# Altium schematic sheet mimsy2.SchDoc
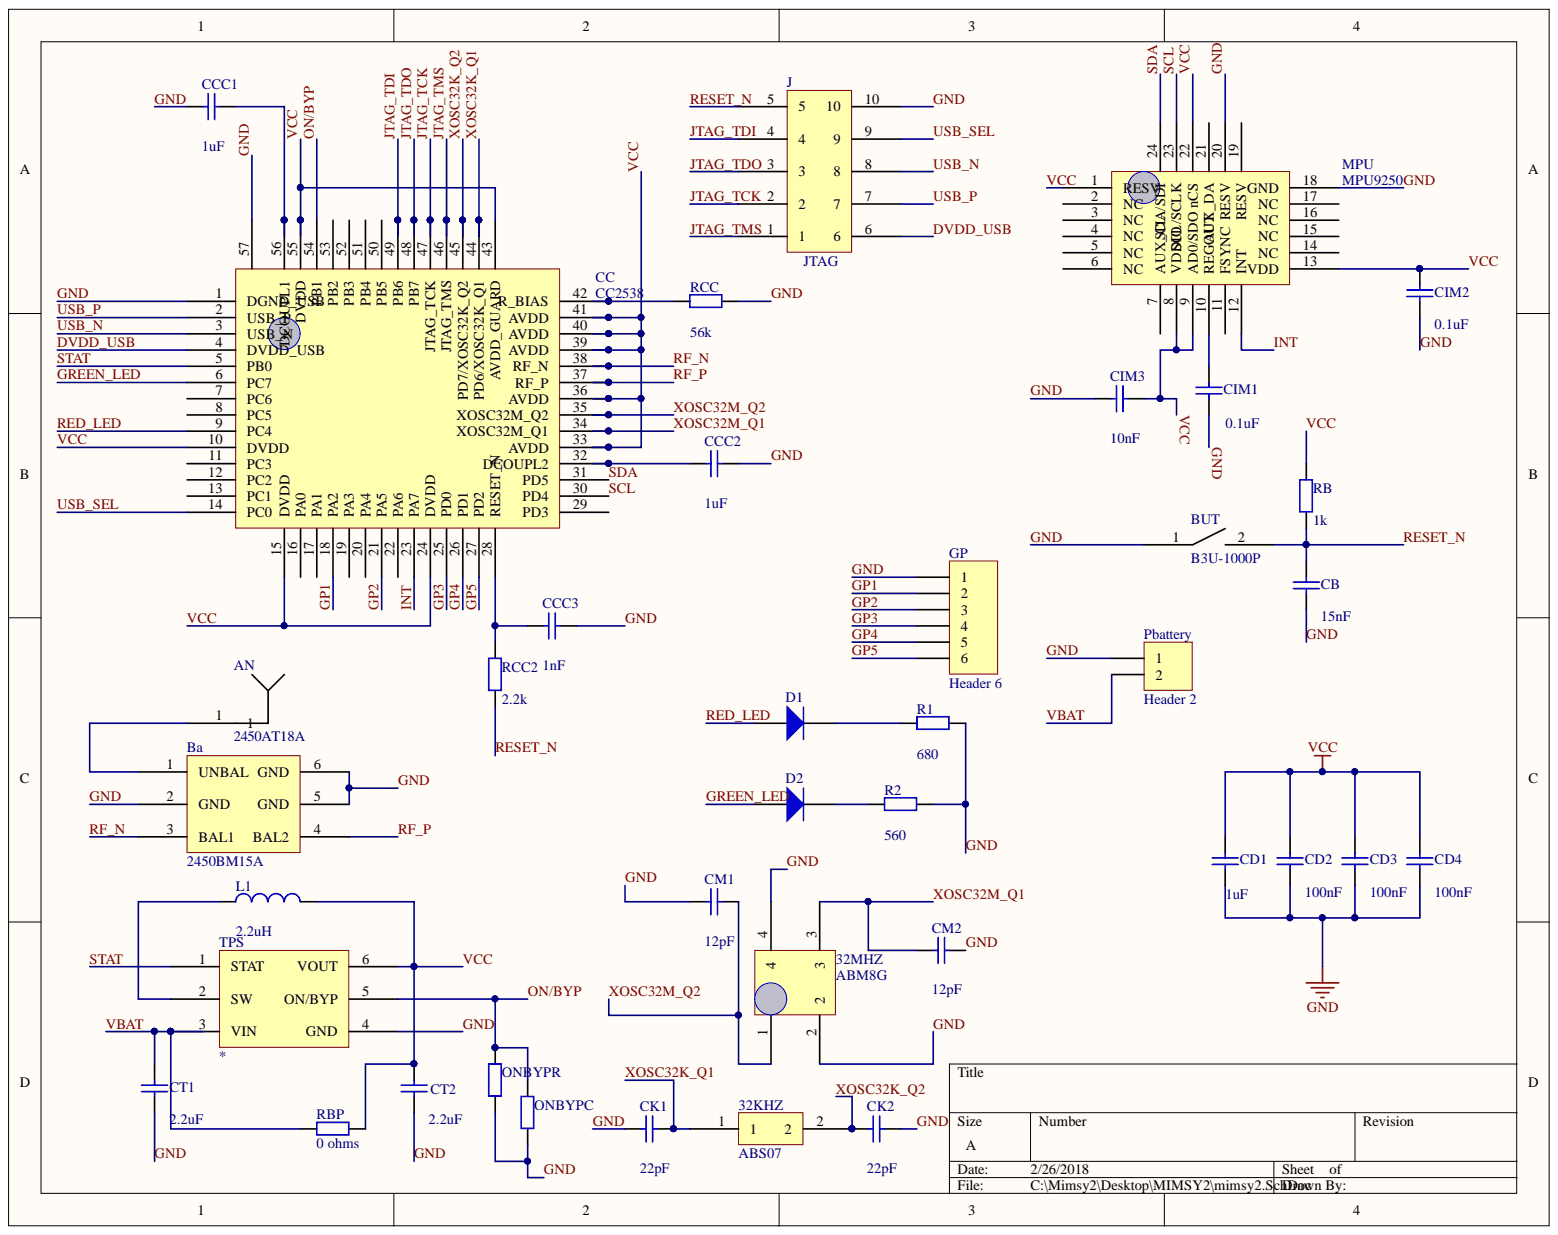
[diagram p0: mimsy2.SchDoc]

## Parts
32KHZ  ABS07  [32K Xtal]
32MHZ  ABM8G  [ABM8G]
AN  2450AT18A  [2450AT]
Ba  2450BM15A  [2450BM15A]
BUT  B3U-1000P  [B3U-1000P]
CB  value 15nF  [0402]
CC  CC2538  [QFN127P600-8N - NO GROUND PADS]
CCC1  value 1uF  [0402]
CCC2  value 1uF  [0402]
CCC3  value 1nF  [0402]
CD1  value 1uF  [0402]
CD2  value 100nF  [0402]
CD3  value 100nF  [0402]
CD4  value 100nF  [0402]
CIM1  value 0.1uF  [0402]
CIM2  value 0.1uF  [0402]
CIM3  value 10nF  [0402]
CK1  value 22pF  [0402]
CK2  value 22pF  [0402]
CM1  value 12pF  [0402]
CM2  value 12pF  [0402]
CT1  value 2.2uF  [0402]
CT2  value 2.2uF  [0402]
D1  (no value)  [0402-A]
D2  (no value)  [0402-A]
GP  Header 6  [HDR1X6]
J  JTAG  [JTAG2x5]
L1  value 2.2uH  [0402-A]
MPU  MPU9250  [QFN40P300X300X105-25N]
ONBYPC  (no value)  [0402]
ONBYPR  (no value)  [0402]
Pbattery  Header 2  [HDR1X2 - 2mm pitch]
R1  value 680  [0402]
R2  value 560  [0402]
RB  value 1k  [0402]
RBP  0 ohms  [0402]
RCC  value 56k  [0402]
RCC2  value 2.2k  [0402]
TPS  (no value)  [TPS62730]

## Nets
DVDD_USB: CC.4(DVDD_USB) J.6
GND: 32MHZ.2 32MHZ.4 Ba.2(GND) Ba.5(GND) Ba.6(GND) BUT.1 CB.1 CC.1(DGND_USB) CC.57 CCC1.1 CCC2.2 CCC3.2 CD1.1 CD2.1 CD3.1 CD4.1 CIM1.1 CIM2.1 CIM3.1 CK1.1 CK2.2 CM1.1 CM2.2 CT1.1 CT2.1 GP.1 J.10 MPU.18(GND) MPU.20(RESV) ONBYPC.1 ONBYPR.1 Pbattery.1 R1.2 R2.2 RCC.2 TPS.4(GND)
GP1: CC.18(PA2) GP.2
GP2: CC.21(PA5) GP.3
GP3: CC.25(PD0) GP.4
GP4: CC.26(PD1) GP.5
GP5: CC.27(PD2) GP.6
GREEN_LED: CC.6(PC7) D2.1
INT: CC.23(PA7) MPU.12(INT)
JTAG_TCK: CC.47(JTAG_TCK) J.2
JTAG_TDI: CC.49(PB6) J.4
JTAG_TDO: CC.48(PB7) J.3
JTAG_TMS: CC.46(JTAG_TMS) J.1
ON/BYP: CC.54(PB1) ONBYPC.2 ONBYPR.2 TPS.5(ON/BYP)
RED_LED: CC.9(PC4) D1.1
RESET_N: BUT.2 CB.2 J.5 RB.1 RCC2.1
RF_N: Ba.3(BAL1) CC.38(RF_N)
RF_P: Ba.4(BAL2) CC.37(RF_P)
SCL: CC.30(PD4) MPU.23(SCL/SCLK)
SDA: CC.31(PD5) MPU.24(SDA/SDI)
STAT: CC.5(PB0) TPS.1(STAT)
USB_N: CC.3(USB_N) J.8
USB_P: CC.2(USB_P) J.7
USB_SEL: CC.14(PC0) J.9
VBAT: CT1.2 Pbattery.2 RBP.1 TPS.3(VIN)
VCC: CC.10(DVDD) CC.15(DVDD) CC.24(DVDD) CC.33(AVDD) CC.36(AVDD) CC.39(AVDD) CC.40(AVDD) CC.41(AVDD) CC.43(AVDD_GUARD) CC.55(DVDD) CD1.2 CD2.2 CD3.2 CD4.2 CIM2.2 CIM3.2 CT2.2 L1.2 MPU.1(RESV) MPU.8(VDDIO) MPU.9(AD0/SDO) MPU.13(VDD) MPU.22(nCS) RB.2 RBP.2 TPS.6(VOUT)
XOSC32K_Q1: 32KHZ.1 CC.44(PD6/XOSC32K_Q1) CK1.2
XOSC32K_Q2: 32KHZ.2 CC.45(PD7/XOSC32K_Q2) CK2.1
XOSC32M_Q1: 32MHZ.3 CC.34(XOSC32M_Q1) CM2.1
XOSC32M_Q2: 32MHZ.1 CC.35(XOSC32M_Q2) CM1.2
NetAN_1: AN.1 Ba.1(UNBAL)
NetCC_28: CC.28(RESET_N) CCC3.1 RCC2.2
NetCC_32: CC.32(DCOUPL2) CCC2.1
NetCC_42: CC.42(R_BIAS) RCC.1
NetCC_56: CC.56(DCOUPL1) CCC1.2
NetCIM1_2: CIM1.2 MPU.10(REGOUT)
NetD1_2: D1.2 R1.1
NetD2_2: D2.2 R2.1
NetL1_1: L1.1 TPS.2(SW)

Not connected: CC 7(PC6) 8(PC5) 11(PC3) 12(PC2) 13(PC1) 16(PA0) 17(PA1) 19(PA3) 20(PA4) 22(PA6) 29(PD3) 50(PB5) 51(PB4) 52(PB3) 53(PB2); MPU 2(NC) 3(NC) 4(NC) 5(NC) 6(NC) 7(AUX_CL) 11(FSYNC) 14(NC) 15(NC) 16(NC) 17(NC) 19(RESV) 21(AUX_DA)

## Library data of the parts
32KHZ = ABS07: 32kHz Xtal; Component Kind: Standard; Library Name: Schlib1.SchLib
32MHZ = ABM8G: 32MH Xtal; Component Kind: Standard; Library Name: Schlib1.SchLib
AN = 2450AT18A: 2.4Ghz Ceramic Antenna; Component Kind: Standard; Library Name: Integrated_Library.IntLib
Ba = 2450BM15A: 2.4GHz Balun; Component Kind: Standard; Library Name: Integrated_Library.IntLib
CB CCC1 CCC2 CD1-CD4 CIM1-CIM3 CK1 CK2 CM1 CM2 CT1 CT2 = Cap Semi: Capacitor (Semiconductor SIM Model); Component Kind: Standard; LatestRevisionDate: 29-May-2009; LatestRevisionNote: IPC-7351 Footprint Added.; Library Name: Miscellaneous Devices.IntLib; PackageDescription: Chip Capacitor; PackageReference: C1206; Published: 8-Jun-2000; Signal Integrity: Cap Semi; Simulation: CAP; Publisher: Altium Limited
CC = CC2538: 56-VFQFN; Component Kind: Standard; Library Name: Schlib1.SchLib
CCC3 = Cap Semi: Capacitor (Semiconductor SIM Model); Value: 1nF; Component Kind: Standard; LatestRevisionDate: 29-May-2009; LatestRevisionNote: IPC-7351 Footprint Added.; Library Name: Miscellaneous Devices.IntLib; PackageDescription: Chip Capacitor; PackageReference: C1206; Published: 8-Jun-2000; Signal Integrity: Cap Semi; Simulation: CAP; Publisher: Altium Limited
D1 D2 = Diode: Default Diode; Code_JEDEC: DO-214-AB; Component Kind: Standard; LatestRevisionDate: 17-Jul-2002; LatestRevisionNote: Re-released for DXP Platform.; Library Name: Miscellaneous Devices.IntLib; PackageDescription: DO-214-AB/SMC; 2 C-Bend Leads; Body 7.9 x 5.9 mm, inc. leads (LxW); PackageReference: SMC; PackageVersion: Sep-1996; Published: 8-Jun-2000; Signal Integrity: Diode; Simulation: DIODE; Publisher: Altium Limited
GP = Header 6: Header, 6-Pin; Component Kind: Standard; LatestRevisionDate: 17-Jul-2002; LatestRevisionNote: Re-released for DXP Platform.; Library Name: Miscellaneous Connectors.IntLib; Signal Integrity: Connector; Publisher: Altium Limited
L1 = Inductor: Code_IEC: 1005; Value: 2.2uH; Code_JEITA: 0402; Component Kind: Standard; Description: Inductor; LatestRevisionDate: 29-May-2009; LatestRevisionNote: IPC-7351 Footprint Added.; Library Name: Miscellaneous Devices.IntLib; PackageDescription: Chip Inductor; PackageReference: 0402-A; Published: 8-Jun-2000; Signal Integrity: Inductor; Simulation: INDUCTOR; Publisher: Altium Limited
MPU = MPU9250: 24-QFN; Component Kind: Standard; Library Name: Integrated_Library.IntLib
ONBYPC ONBYPR RBP = Res2: Resistor; Value: 1K; Component Kind: Standard; LatestRevisionDate: 17-Jul-2002; LatestRevisionNote: Re-released for DXP Platform.; Library Name: Miscellaneous Devices.IntLib; PackageDescription: Axial Device, Thru-Hole; 2 Leads; 0.4 in Pin Spacing; PackageReference: AXIAL-0.4; Published: 8-Jun-2000; Signal Integrity: Res; Simulation: RESISTOR; Publisher: Altium Limited
Pbattery = Header 2: Header, 2-Pin; Component Kind: Standard; LatestRevisionDate: 17-Jul-2002; LatestRevisionNote: Re-released for DXP Platform.; Library Name: Miscellaneous Connectors.IntLib; Signal Integrity: Connector; Publisher: Altium Limited
R1 R2 RB RCC RCC2 = Res2: Resistor; Component Kind: Standard; LatestRevisionDate: 17-Jul-2002; LatestRevisionNote: Re-released for DXP Platform.; Library Name: Miscellaneous Devices.IntLib; PackageDescription: Axial Device, Thru-Hole; 2 Leads; 0.4 in Pin Spacing; PackageReference: AXIAL-0.4; Published: 8-Jun-2000; Signal Integrity: Res; Simulation: RESISTOR; Publisher: Altium Limited
TPS = TPS62730: Component Kind: Standard; Library Name: Schlib1.SchLib
BUT = B3U-1000P: Component Kind: Standard; Library Name: Integrated_Library.IntLib
J = JTAG: Component Kind: Standard; Library Name: Integrated_Library.IntLib
